# Altium schematic sheet Lab5.SchDoc(Lab5)
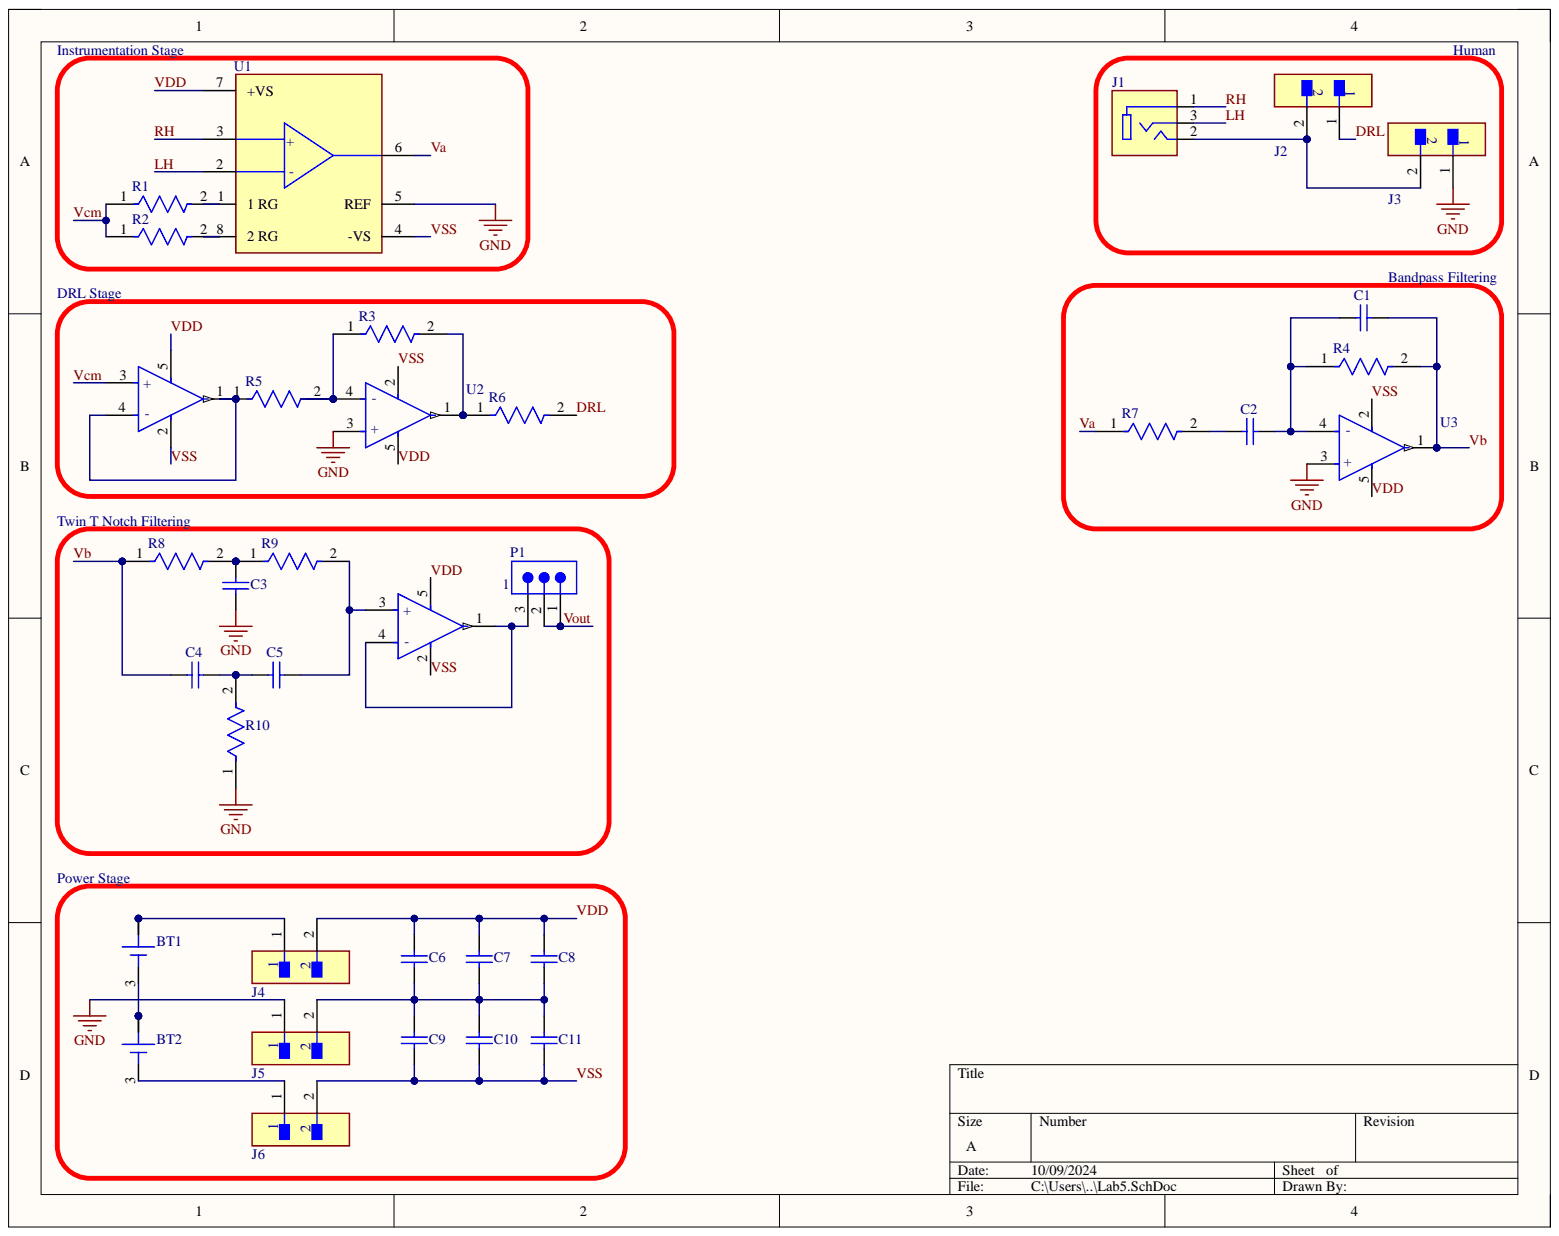
[diagram p0: Lab5.SchDoc]

## Parts
1  TLV521DCKT  [FP-DCK0005A-MFG]  (value not drawn)
BT1  BK-913-TR  [BAT_BK-913-TR]  (value not drawn)
BT2  BK-913-TR  [BAT_BK-913-TR]  (value not drawn)
C1  C0805C225K9PACTU  [CAPC2013X145X50ML20T25]  (value not drawn)
C2  C0805C225K9PACTU  [CAPC2013X145X50ML20T25]  (value not drawn)
C3  C0805C225K9PACTU  [CAPC2013X145X50ML20T25]  (value not drawn)
C4  C0805C225K9PACTU  [CAPC2013X145X50ML20T25]  (value not drawn)
C5  C0805C225K9PACTU  [CAPC2013X145X50ML20T25]  (value not drawn)
C6  C0805C225K9PACTU  [CAPC2013X145X50ML20T25]  (value not drawn)
C7  C0805C225K9PACTU  [CAPC2013X145X50ML20T25]  (value not drawn)
C8  C0805C225K9PACTU  [CAPC2013X145X50ML20T25]  (value not drawn)
C9  C0805C225K9PACTU  [CAPC2013X145X50ML20T25]  (value not drawn)
C10  C0805C225K9PACTU  [CAPC2013X145X50ML20T25]  (value not drawn)
C11  C0805C225K9PACTU  [CAPC2013X145X50ML20T25]  (value not drawn)
J1  SJ-3523-SMT-TR  [CUI_SJ-3523-SMT-TR]  (value not drawn)
J2  TSW-102-07-L-S  [FP-TSW-102-07-L-S-MFG]  (value not drawn)
J3  TSW-102-07-L-S  [FP-TSW-102-07-L-S-MFG]  (value not drawn)
J4  TSW-102-07-L-S  [FP-TSW-102-07-L-S-MFG]  (value not drawn)
J5  TSW-102-07-L-S  [FP-TSW-102-07-L-S-MFG]  (value not drawn)
J6  TSW-102-07-L-S  [FP-TSW-102-07-L-S-MFG]  (value not drawn)
P1  TSW-103-07-F-S  [SMTC-TSW-103-07-X-S]  (value not drawn)
R1  CRCW080516K0FKEA  [FP-CRCW0805-e3-IPC_C]  (value not drawn)
R2  CRCW080516K0FKEA  [FP-CRCW0805-e3-IPC_C]  (value not drawn)
R3  CRCW080516K0FKEA  [FP-CRCW0805-e3-IPC_C]  (value not drawn)
R4  CRCW080516K0FKEA  [FP-CRCW0805-e3-IPC_C]  (value not drawn)
R5  CRCW080516K0FKEA  [FP-CRCW0805-e3-IPC_C]  (value not drawn)
R6  CRCW080516K0FKEA  [FP-CRCW0805-e3-IPC_C]  (value not drawn)
R7  CRCW080516K0FKEA  [FP-CRCW0805-e3-IPC_C]  (value not drawn)
R8  CRCW080516K0FKEA  [FP-CRCW0805-e3-IPC_C]  (value not drawn)
R9  CRCW080516K0FKEA  [FP-CRCW0805-e3-IPC_C]  (value not drawn)
R10  CRCW080516K0FKEA  [FP-CRCW0805-e3-IPC_C]  (value not drawn)
U1  AD620ARZ-REEL7  [FP-R-8-IPC_B]  (value not drawn)
U2  TLV521DCKT  [FP-DCK0005A-MFG]  (value not drawn)
U3  TLV521DCKT  [FP-DCK0005A-MFG]  (value not drawn)
  TLV521DCKT  [FP-DCK0005A-MFG]  (value not drawn)

## Nets
GND: C3.1 J3.1 J5.1 R10.1 U1.5(REF) U2.3(+) U3.3(+)
DRL: J2.1 R6.2
LH: J1.3 U1.2
RH: J1.1 U1.3
Va: R7.1 U1.6
Vb: C1.2 C4.1 R4.2 R8.1 U3.1
Vcm: R1.1 R2.1 .3(+)
VDD: 1.5 C6.2 C7.2 C8.2 J4.2 U1.7(+VS) U2.5 U3.5 .5
Vout: P1.1 P1.2
VSS: 1.2 C9.1 C10.1 C11.1 J6.2 U1.4(-VS) U2.2 U3.2 .2
Net 1_1: 1.1 1.4(-) P1.3
Net 1_3: 1.3(+) C5.2 R9.2
Net _1: R5.1 .1 .4(-)
NetBT1_1: BT1.1 BT1.2 J4.1
NetBT1_3: BT1.3 BT2.1 BT2.2
NetBT2_3: BT2.3 J6.1
NetC1_1: C1.1 C2.2 R4.1 U3.4(-)
NetC2_1: C2.1 R7.2
NetC3_2: C3.2 R8.2 R9.1
NetC4_2: C4.2 C5.1 R10.2
NetC6_1: C6.1 C7.1 C8.1 C9.2 C10.2 C11.2 J5.2
NetJ1_2: J1.2 J2.2 J3.2
NetR1_2: R1.2 U1.1(1_RG)
NetR2_2: R2.2 U1.8(2_RG)
NetR3_1: R3.1 R5.2 U2.4(-)
NetR3_2: R3.2 R6.1 U2.1

## Library data of the parts
1 U2 U3  = CMP-04895-000072-1: IC CMOS 1 CIRCUIT SC70-5; Bandwidth: 6kHz; Input Bias Current: 1pA; Input Offset Voltage (Vos): 100uV; Number of Amplifiers: 1; Operating Supply Current: 350nA; Output Current per Channel: 12mA; Slew Rate: 2.5V/µs; Case/Package: SC; Component Kind: Standard; Gain Bandwidth Product: 6kHz; Lead Free: Lead Free; Library Name: Altium Content Vault; Max Operating Temperature: 125°C; Min Operating Temperature: -40°C; Mount: Surface Mount; Number of Channels: 1; Number of Circuits: 1; Number of Pins: 5; Packaging: Cut Tape; REACH SVHC: No SVHC
BT1 BT2 = BK-913-TR: Battery Retainer Coin, 20.0mm 1 Cell PC Pin; MF: MPD (Memory Protection Devices); Check_prices: https://www.snapeda.com/parts/BK-913-TR/Memory+Protection+Devices/view-part/?ref=eda; Component Kind: Standard; Library Name: Lab5.SchLib; MP: BK-913-TR; Package: None; SnapEDA_Link: https://www.snapeda.com/parts/BK-913-TR/Memory+Protection+Devices/view-part/?ref=snap
C1-C11 = CMP-1036-04819-2: Manufacturer: Kemet; Component Kind: Standard; Library Name: Altium Content Vault; Part Number: C0805C225K9PACTU
J1 = SJ-3523-SMT-TR: <b>Audio Jack Connector</b> 3.5 mm Low Profile Surface Mount Stereo Jack, 3 Pin Right-Angle; Availability: Unavailable; Component Kind: Standard; Library Name: Lab5.SchLib; MP: SJ-3523-SMT-TR; Package: None; Price: None
J2-J6 = CMP-02766-001428-1: CONN HEADER VERT 2POS 2.54MM; Connector Type: Male Pin; Current Rating: 7.6A; Gender: Male; Max Voltage Rating (AC): 550V; Number of Contacts: 2; Color: Black; Component Kind: Standard; Contact Material: Bronze; Contact Mating Length: 0.23inch; Contact Plating: Gold; Contact Type: Male Pin; Depth: 2.54mm; Housing Color: Black; Housing Material: Polyester; Lead Free: Lead Free; Length: 5.08mm; Library Name: Altium Content Vault; Max Current Rating: 7.6A; Max Operating Temperature: 125°C; Min Operating Temperature: -55°C; Mount: Through Hole; Number of Positions: 2; Number of Rows: 1; Orientation: Straight; Package Quantity: 1; Packaging: Bulk; Pitch: 2.54mm; Radiation Hardening: No; REACH SVHC: No SVHC; RoHS Compliant: Yes; Series: TSW; Termination: Solder; Voltage Rating: 550V; Width: 2.54mm
P1 = CMP-1024-00331-1: 0.025" SQ Post Header, Through-hole, Vertical, -55 to 125 degC, 2.54 mm Pitch, 3-Pin, Male, RoHS; Manufacturer: Samtec; Pitch: 2.54 mm; Component Kind: Standard; ComponentLink1Description: Manufacturer URL; ComponentLink1URL: http://www.samtec.com; ComponentLink2Description: Datasheet; ComponentLink2URL: http://www.samtec.com/documents/webfiles/pdf/TSW_TH.PDF; ComponentLink3Description: Package Specification; ComponentLink3URL: http://www.samtec.com/documents/webfiles/cpdf/TSW-XXX-XX-XXX-X-XX-XXX-MKT.pdf; DatasheetVersion: F-214; Library Name: Altium Content Vault; Mounting Technology: Through-hole; Orientation: Vertical; PackageDescription: .025[0.64] SQ. Post Terminal Strip Assembly; PackageReference: TSW-103-07-X-S; PackageVersion: DM, 8/1988; PartNumber: TSW-103-07-F-S; RoHS: True; Temperature Range: -55 to 125 degC
R1-R10 = CMP-2001-04979-2: RES Thick Film, 16kΩ, 1%, 0.125W, 100ppm/°C, 0805; Max Power Dissipation: 125mW; Resistance: 16kR; Voltage Rating: 150V; Case Code (Imperial): 0805; Case Code (Metric): 2012; Case/Package: 0805; Component Kind: Standard; Composition: Thick Film; Contact Plating: Tin; Depth: 1.25mm; Features: Automotive AEC-Q200; Height: 0.45mm; Lead Free: Lead Free; Length: 2mm; Library Name: Altium Content Vault; Max Operating Temperature: 155°C; Military Standard: Not; Min Operating Temperature: -55°C; Mount: Surface Mount; Number of Pins: 2; Number of Terminations: 2; Package Quantity: 10; Packaging: Tape and Reel; Power Rating: 125mW; Radiation Hardening: No; Temperature Coefficient: 100ppm/°C; Termination: SMD/SMT; Tolerance: 1%; Voltage Rating (DC): 150V; Weight: 0.000194oz; Width: 1.25mm
U1 = CMP-09116-000354-1: IC INST AMP 1 CIRCUIT 8SOIC; -3db Bandwidth: 1MHz; Bandwidth: 800kHz; Common Mode Rejection Ratio: 93dB; Dual Supply Voltage: 15V; Input Bias Current: 500pA; Input Offset Voltage (Vos): 30mV; Input Resistance: 10GR; Max Dual Supply Voltage: 18V; Max Power Dissipation: 650mW; Min Dual Supply Voltage: 2.3V; Min Supply Voltage: 4.6V; Nominal Supply Current: 900uA; Number of Channels: 1; Operating Supply Current: 1.3mA; Output Current per Channel: 18mA; Power Supply Rejection Ratio (PSRR): 140dB; Slew Rate: 1.2V/µs; Case/Package: SOIC; Component Kind: Standard; Contact Plating: Tin; Lead Free: Contains Lead; Library Name: Altium Content Vault; Max Operating Temperature: 125°C; Min Operating Temperature: -55°C; Mount: Surface Mount; Number of Circuits: 1; Number of Elements: 1; Number of Pins: 8; Operating Supply Voltage: 18V; Package Quantity: 1; Packaging: Tape and Reel; Power Dissipation: 650mW; Radiation Hardening: No; RoHS Compliant: Yes; Series: -; Supply Current: 900uA
